# Altium schematic sheet General Overview.SchDoc
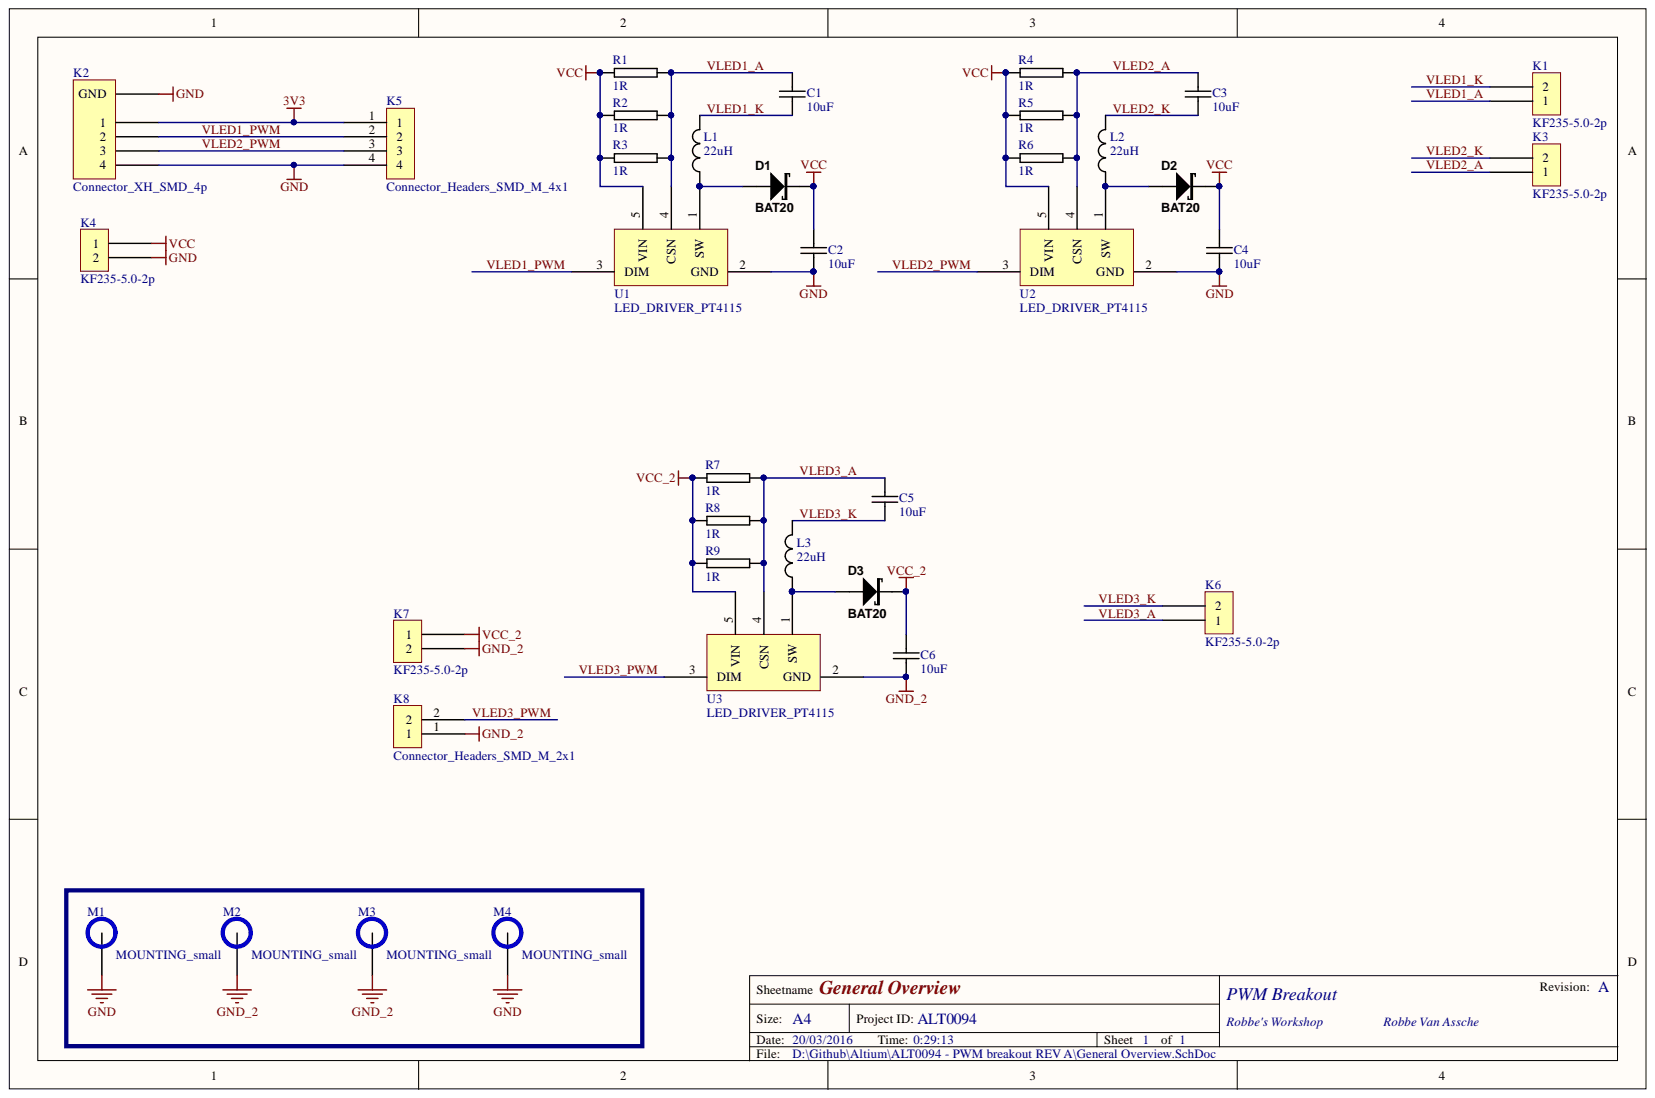
[diagram p0: General Overview.SchDoc]

## Parts
C1  10uF  [1210C-GENERIC]
C2  10uF  [1210C-GENERIC]
C3  10uF  [1210C-GENERIC]
C4  10uF  [1210C-GENERIC]
C5  10uF  [1210C-GENERIC]
C6  10uF  [1210C-GENERIC]
D1  BAT20  [SOD323]
D2  BAT20  [SOD323]
D3  BAT20  [SOD323]
K1  KF235-5.0-2p  [KF235-5.0-2p]
K2  Connector_XH_SMD_4p  [2.54_4p_THT+SMD]
K3  KF235-5.0-2p  [KF235-5.0-2p]
K4  KF235-5.0-2p  [KF235-5.0-2p]
K5  Connector_Headers_SMD_M_4x1  [Header_Male_2.54 4x1_COMBO]
K6  KF235-5.0-2p  [KF235-5.0-2p]
K7  KF235-5.0-2p  [KF235-5.0-2p]
K8  Connector_Headers_SMD_M_2x1  [Header_Male_2.54 2x1_COMBO]
L1  22uH  [CD54]
L2  22uH  [CD54]
L3  22uH  [CD54]
M1  MOUNTING_small  [MOUNTING M3 small]
M2  MOUNTING_small  [MOUNTING M3 small]
M3  MOUNTING_small  [MOUNTING M3 small]
M4  MOUNTING_small  [MOUNTING M3 small]
R1  1R  [0805R-GENERIC]
R2  1R  [0805R-GENERIC]
R3  1R  [0805R-GENERIC]
R4  1R  [0805R-GENERIC]
R5  1R  [0805R-GENERIC]
R6  1R  [0805R-GENERIC]
R7  1R  [0805R-GENERIC]
R8  1R  [0805R-GENERIC]
R9  1R  [0805R-GENERIC]
U1  LED_DRIVER_PT4115  [SOT89-5]
U2  LED_DRIVER_PT4115  [SOT89-5]
U3  LED_DRIVER_PT4115  [SOT89-5]

## Nets
3V3: K2.1 K5.1
GND: C2.2 C4.2 K2.0(GND) K2.4 K4.2 K5.4 M1.1 M4.1 U1.2(GND) U2.2(GND)
GND_2: C6.2 K7.2 K8.1 M2.1 M3.1 U3.2(GND)
VCC: C2.1 C4.1 D1.K D2.K K4.1 R1.1 R2.1 R3.1 R4.1 R5.1 R6.1 U1.5(VIN) U2.5(VIN)
VCC_2: C6.1 D3.K K7.1 R7.1 R8.1 R9.1 U3.5(VIN)
VLED1_A: C1.1 K1.1 R1.2 R2.2 R3.2 U1.4(CSN)
VLED1_K: C1.2 K1.2 L1.2
VLED1_PWM: K2.2 K5.2 U1.3(DIM)
VLED2_A: C3.1 K3.1 R4.2 R5.2 R6.2 U2.4(CSN)
VLED2_K: C3.2 K3.2 L2.2
VLED2_PWM: K2.3 K5.3 U2.3(DIM)
VLED3_A: C5.1 K6.1 R7.2 R8.2 R9.2 U3.4(CSN)
VLED3_K: C5.2 K6.2 L3.2
VLED3_PWM: K8.2 U3.3(DIM)
NetD1_A: D1.A L1.1 U1.1(SW)
NetD2_A: D2.A L2.1 U2.1(SW)
NetD3_A: D3.A L3.1 U3.1(SW)

## Library data of the parts
C1-C6 = Passives_Capacitors_1210_1210C-TBD: CAPACITOR 1210 CERAMIC VALUE TO BE DETERMINED; Component Kind: Standard; Library Name: RVA_Passives_Capacitors_1210.SchLib
D1-D3 = BAT20-SOD323: Schottky Diode SOD323 1A 23V; Component Kind: Standard; Supplier 1: Digi-Key; Supplier Part Number 1: 497-3381-2-ND; Library Name: RVA_Silicon Switch_Silicon_Diode_SMD.SchLib
K1 K3 K4 K6 K7 = Connector_Terminal_THT_KF235-5.0-2p: Component Kind: Standard; Library Name: RVA_Connector_Terminal_THT.SchLib
K5 = Connector_Headers_SMD_M_4x1: SMD Header 4x1; Component Kind: Standard; Library Name: RVA_Connector_Headers_SMD.SchLib
K8 = Connector_Headers_SMD_M_2x1: SMD Header 2x1; Component Kind: Standard; Library Name: RVA_Connector_Headers_SMD.SchLib
L1-L3 = CD54_22uH: CD54; Manufacturer: Vishay/Dale; Manufacturer Part Number: IHLP1616ABER1R0M11; Reel: L18; Component Kind: Standard; Supplier 1: Mouser; Supplier Part Number 1: 70-IHLP1616ABER1R0M1; Library Name: RVA_Passives_Inductors_SMD.SchLib
M1-M4 = MOUNTING_small: MOUNTING HOLE - NON INSTALLABLE; Component Kind: Standard; Library Name: RVA_Mechanical_THT.SchLib
R1-R9 = 0805R-1R: RESISTOR 0805 1/3 WATT; REEL: R84; Component Kind: Standard; Supplier 1: Digi-Key; Supplier Part Number 1: 541-1.0TADKR-ND; Library Name: RVA_Passives_Resistors_0805.SchLib
K2 = Connector_XH_SMD_4p: Component Kind: Standard; Library Name: RVA_Connector_XH_SMD.SchLib
U1-U3 = LED_DRIVER_PT4115: Component Kind: Standard; Library Name: RVA_LED_Driver.SchLib
